# Altium schematic sheet CAN.SchDoc
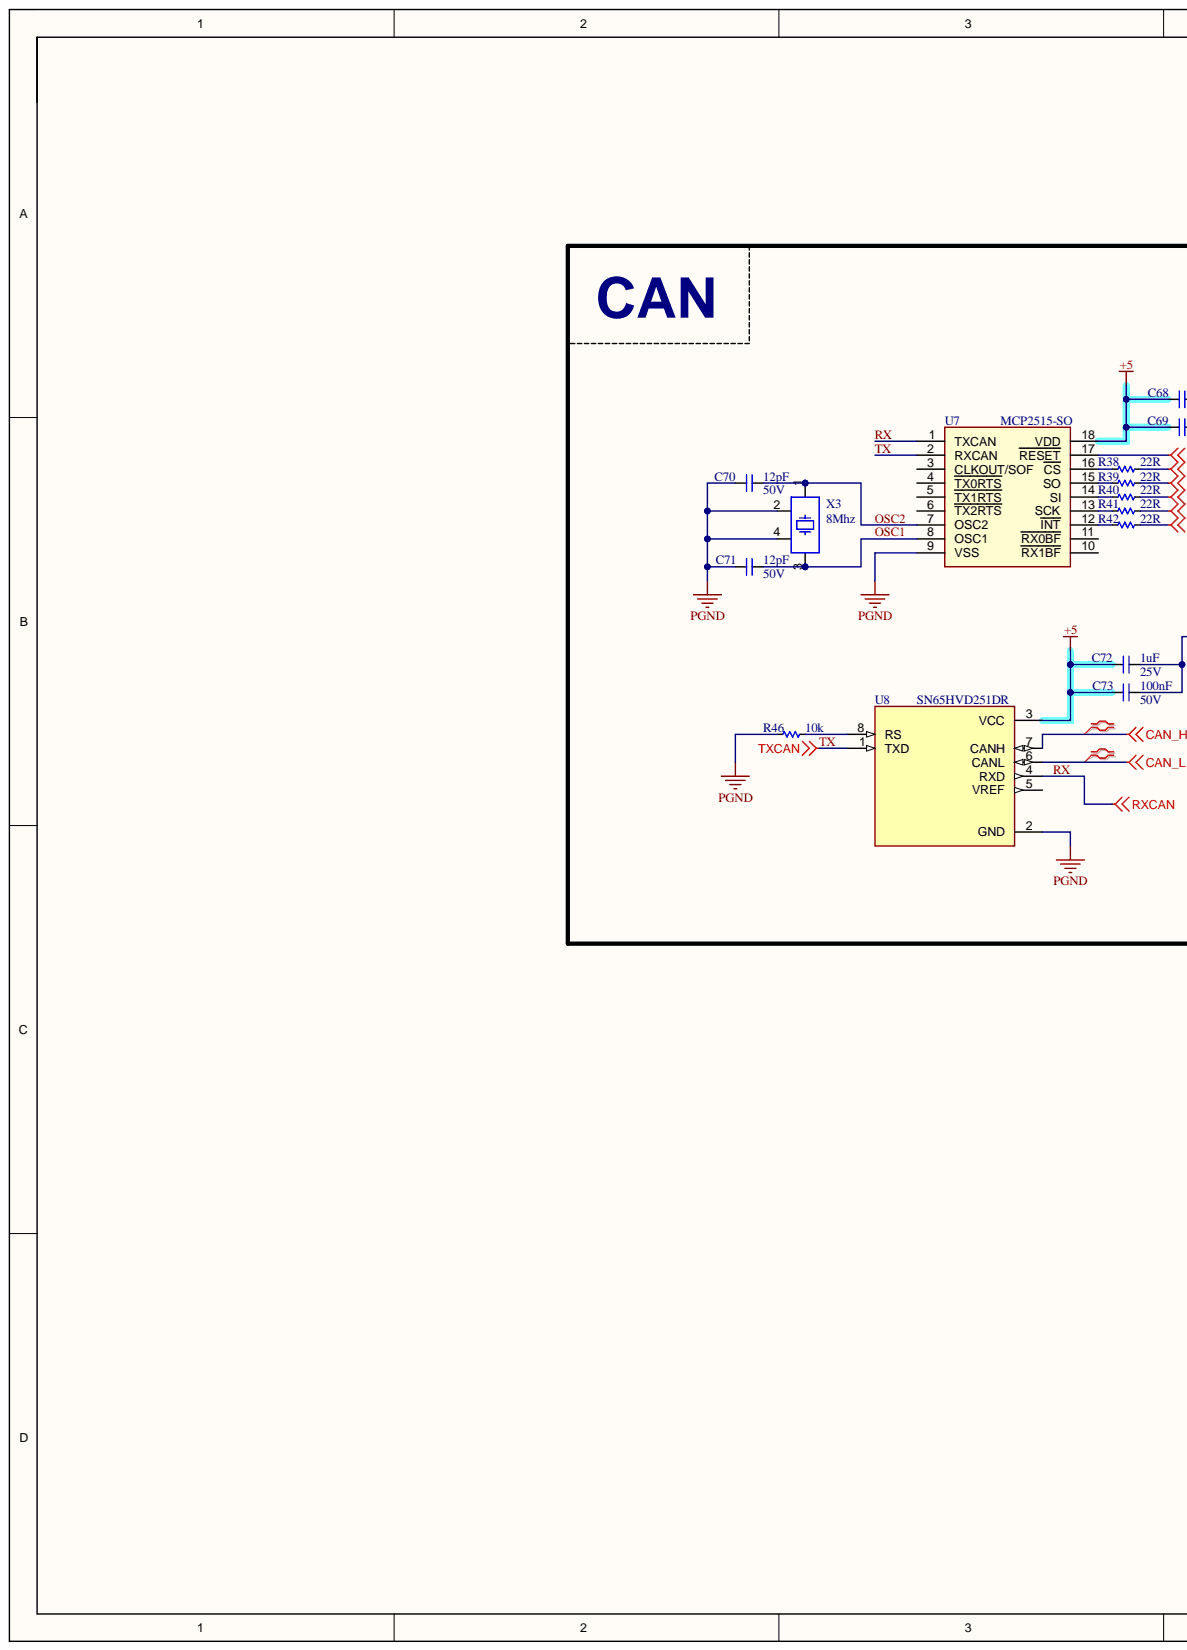
[diagram p0: CAN.SchDoc, left]
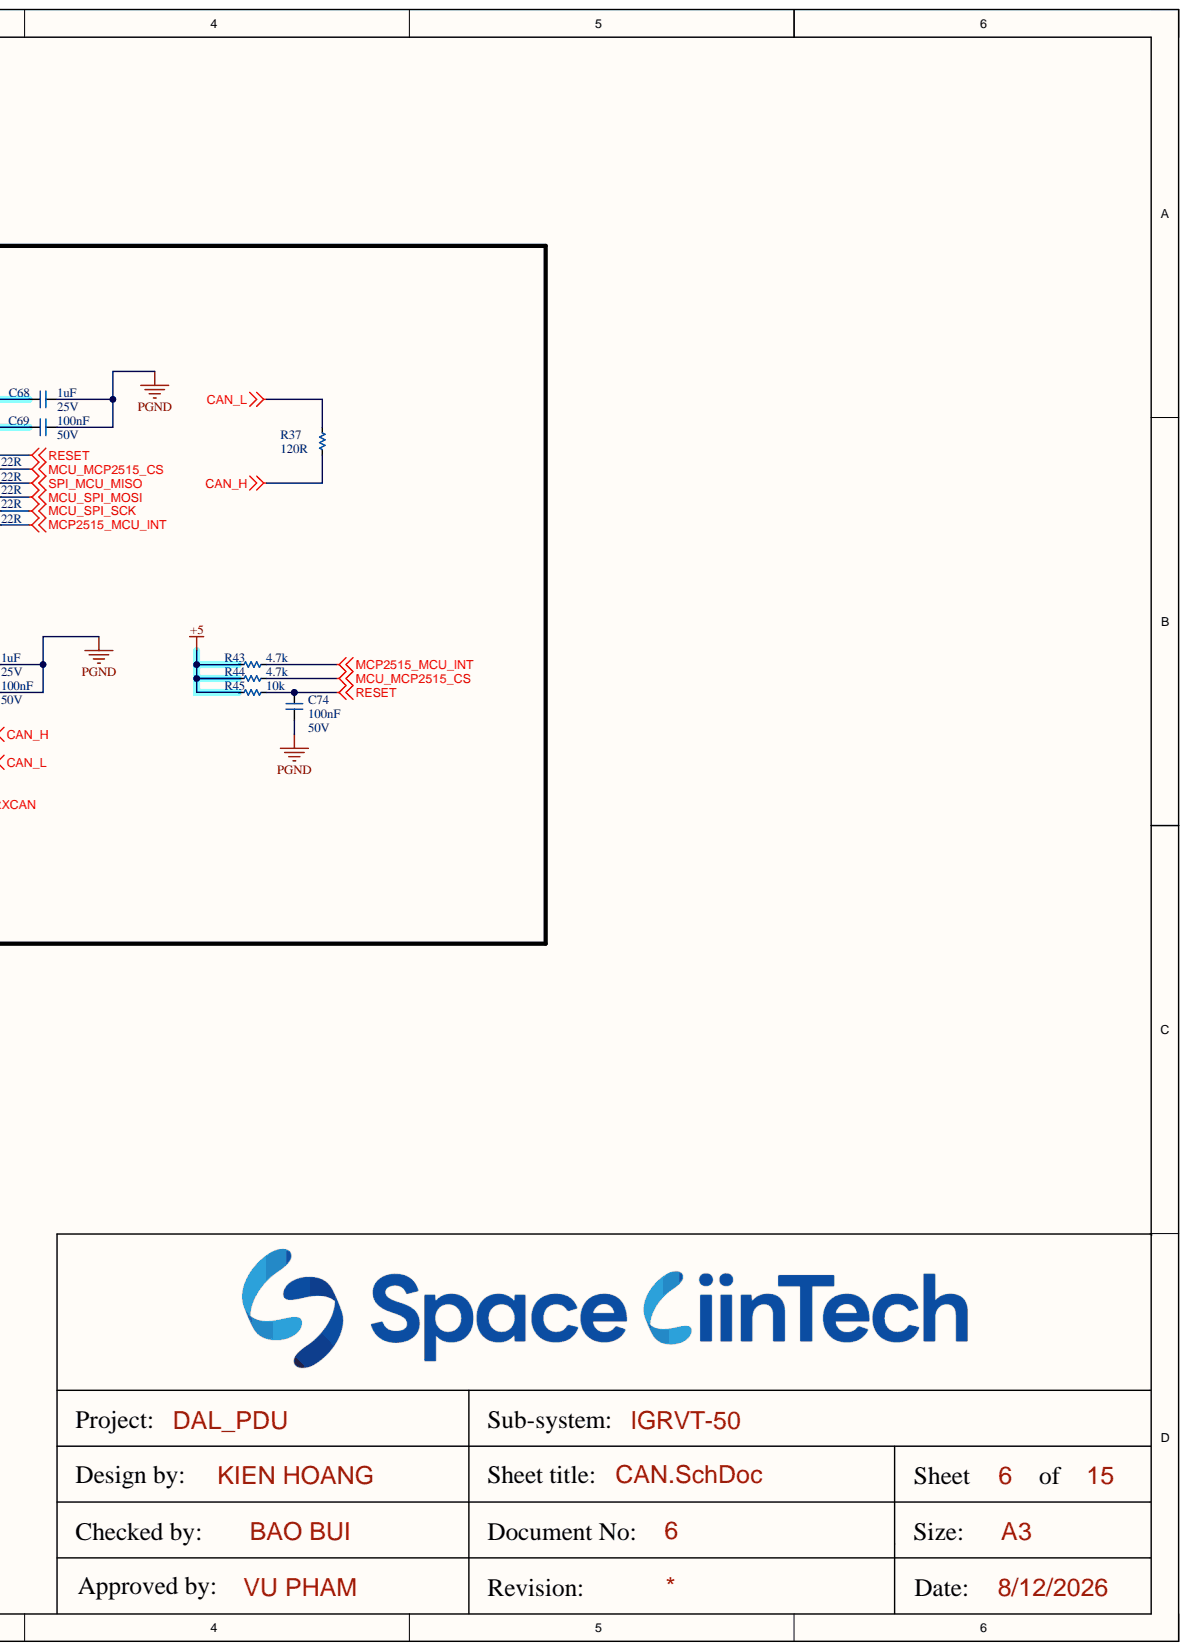
[diagram p1: CAN.SchDoc, right]

## Parts
C68  1uF  Voltage: 25V  [CAP_C_1608X80]
C69  100nF  Voltage: 50V  [CAP_C_1608X80]
C70  12pF  Voltage: 50V  [CAP_C_1608X80]
C71  12pF  Voltage: 50V  [CAP_C_1608X80]
C72  1uF  Voltage: 25V  [CAP_C_1608X80]
C73  100nF  Voltage: 50V  [CAP_C_1608X80]
C74  100nF  Voltage: 50V  [CAP_C_1608X80]
R37  120R  [RES_C_1608X60]
R38  22R  [RES_C_1005X40]
R39  22R  [RES_C_1005X40]
R40  22R  [RES_C_1005X40]
R41  22R  [RES_C_1005X40]
R42  22R  [RES_C_1005X40]
R43  4.7k  [RES_C_1608X60]
R44  4.7k  [RES_C_1608X60]
R45  10k  [RES_C_1608X60]
R46  10k  [RES_C_1608X60]
U7  MCP2515-SO  [SOIC-20]
U8  SN65HVD251DR  [SOIC-8]
X3  8Mhz  [XTAL_3225]

## Nets
+5: C68.1 C69.1 C72.2 C73.1 R43.1 R44.1 R45.1 U7.18(VDD) U8.3(VCC)
CAN_H: R37.1 U8.7(CANH)
CAN_L: R37.2 U8.6(CANL)
MCP2515_MCU_INT: R42.2 R43.2
MCU_MCP2515_CS: R38.2 R44.2
MCU_SPI_MOSI: R40.2
MCU_SPI_SCK: R41.2
PGND: C68.2 C69.2 C70.2 C71.2 C72.1 C73.2 C74.1 R46.1 U7.9(VSS) U8.2(GND) X3.2 X3.4
RESET: C74.2 R45.2 U7.17(RESET)
SPI_MCU_MISO: R39.2
OSC1: C71.1 U7.8(OSC1) X3.3
OSC2: C70.1 U7.7(OSC2) X3.1
RX: U7.1(TXCAN) U8.4(RXD)
TX: U7.2(RXCAN) U8.1(TXD)
NetR38_1: R38.1 U7.16(CS)
NetR39_1: R39.1 U7.15(SO)
NetR40_1: R40.1 U7.14(SI)
NetR41_1: R41.1 U7.13(SCK)
NetR42_1: R42.1 U7.12(INT)
NetR46_2: R46.2 U8.8(RS)

Not connected: U7 3(CLKOUT/SOF) 4(TX0RTS) 5(TX1RTS) 6(TX2RTS) 10(RX1BF) 11(RX0BF); U8 5(VREF)

## Library data of the parts
C68-C74 = _CAP_C_2: CAP; Component Kind: Standard; Library Name: LABLIB.SchLib
R37-R46 = _RES_B_2_2: RES; Component Kind: Standard; Library Name: LABLIB.SchLib
U7 = MCP2515-SO: Stand-Alone CAN Controller with SPI Interface; Component Kind: Standard; Library Name: KIENASIY123_LIB.IntLib
X3 = crystal_4pin: Component Kind: Standard; Library Name: KIENASIY123_LIB.IntLib
U8 = SN65HVD251DR: Component Kind: Standard; Library Name: KIENASIY123_LIB.IntLib
